# Altium schematic sheet MCU.SchDoc(MCU)
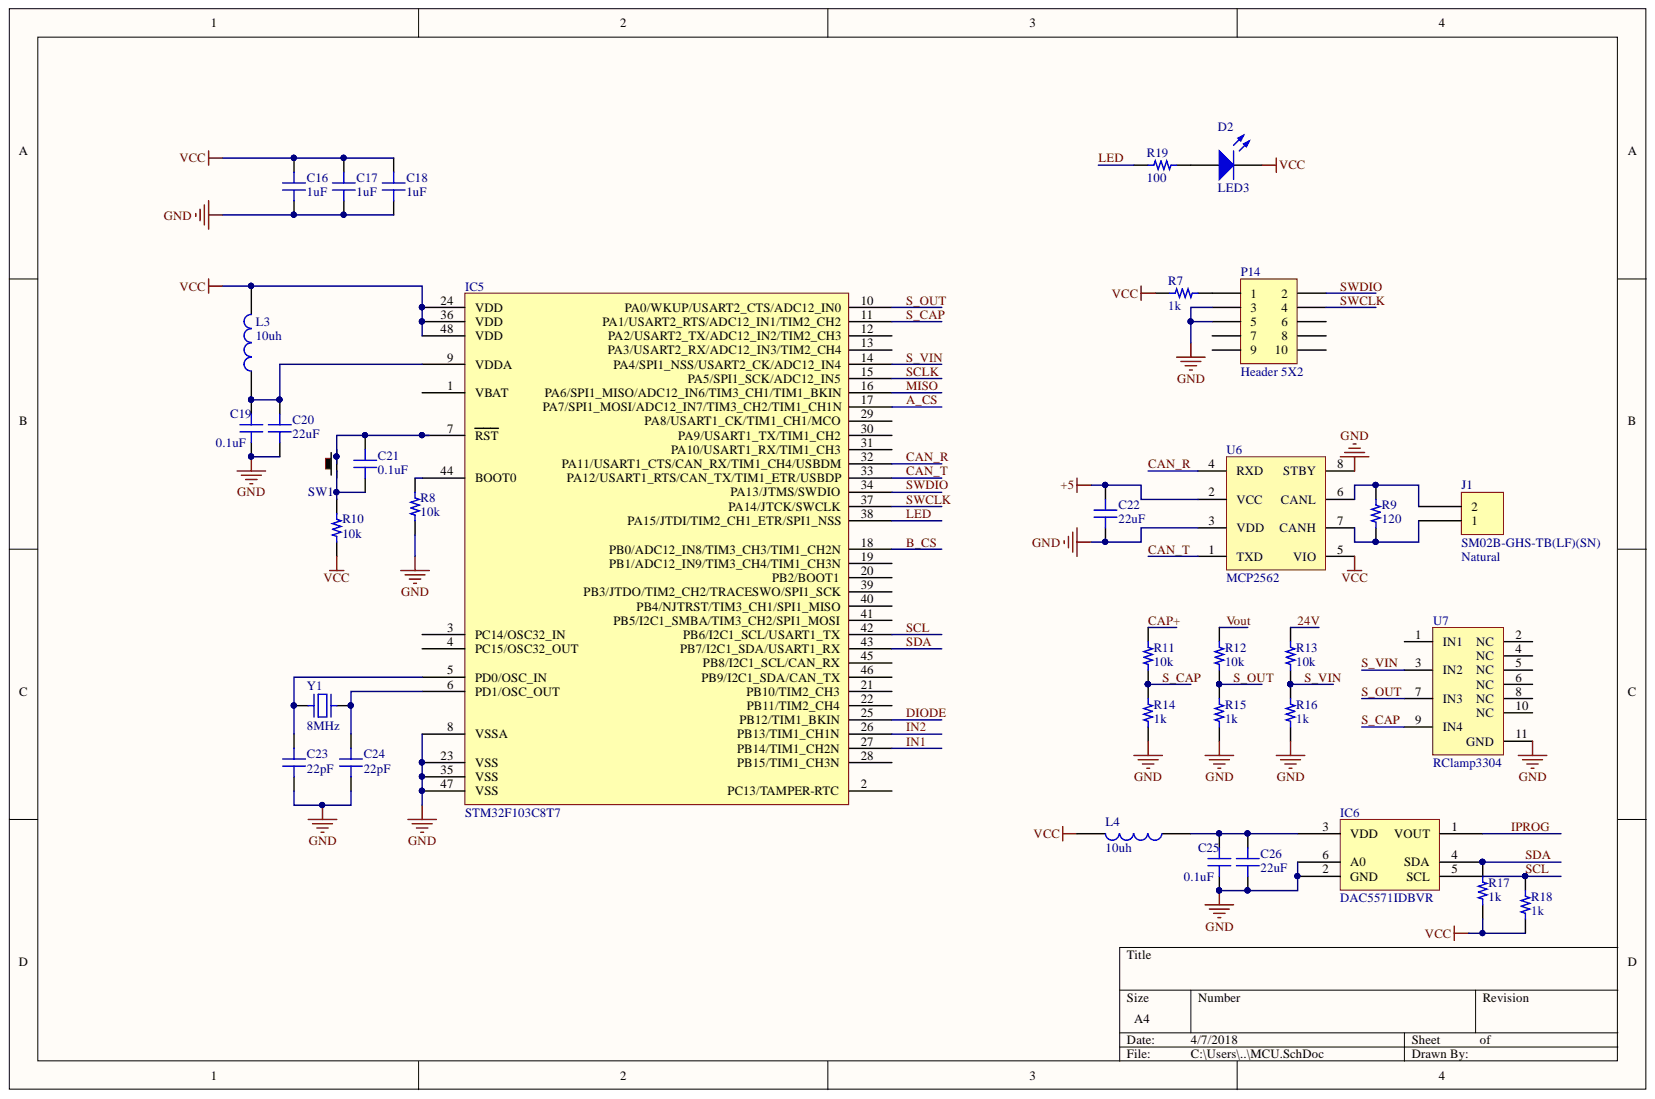
[diagram p0: MCU.SchDoc]

## Parts
C16  1uF  [CAP 0603/1608]
C17  1uF  [CAP 0603/1608]
C18  1uF  [CAP 0603/1608]
C19  0.1uF  [CAP 0603/1608]
C20  22uF  [CAP 0603/1608]
C21  0.1uF  [CAP 0603/1608]
C22  22uF  [CAP 0603/1608]
C23  22pF  [CAP 0603/1608]
C24  22pF  [CAP 0603/1608]
C25  0.1uF  [CAP 0603/1608]
C26  22uF  [CAP 0603/1608]
D2  LED3  [1608[0603]]
IC5  STM32F103C8T7  [ST LQFP-48 7x7MM]
IC6  DAC5571IDBVR  [TI SOT-23-6 DBV]
J1  SM02B-GHS-TB(LF)(SN)  Colour: Natural  [JST SM02B-GHS-TB]
L3  10uh  [1608[0603]]
L4  10uh  [1608[0603]]
P14  Header 5X2  [1.27 5x2 header swd]
R7  1k  [RES 0603/1608]
R8  10k  [RES 0603/1608]
R9  120  [RES 0805/2012]
R10  10k  [RES 0603/1608]
R11  10k  [RES 0603/1608]
R12  10k  [RES 0603/1608]
R13  10k  [RES 0603/1608]
R14  1k  [RES 0603/1608]
R15  1k  [RES 0603/1608]
R16  1k  [RES 0603/1608]
R17  1k  [RES 0603/1608]
R18  1k  [RES 0603/1608]
R19  100  [RES 0603/1608]
SW1  Button  [3.5x2.9 PushButton]  (value not drawn)
U6  MCP2562  [SOIC127P600X175-8N]
U7  RClamp3304  [SON50P260X65_HS-11N]
Y1  8MHz  [NDK NX5032GA]

## Nets
+5: C22.1 U6.2(VCC)
GND: C16.1 C17.1 C18.1 C19.1 C20.2 C22.2 C23.1 C24.1 C25.1 C26.2 IC5.8(VSSA) IC5.23(VSS) IC5.35(VSS) IC5.47(VSS) IC6.2(GND) IC6.6(A0) P14.3 P14.5 R8.1 R14.2 R15.2 R16.2 U6.3(VDD) U6.8(STBY) U7.11(GND)
VCC: C16.2 C17.2 C18.2 D2.2 IC5.24(VDD) IC5.36(VDD) IC5.48(VDD) L3.2 L4.2 R7.1 R10.1 R17.1 R18.1 U6.5(VIO)
24V: R13.2
A_CS: IC5.17(PA7/SPI1_MOSI/ADC12_IN7/TIM3_CH2/TIM1_CH1N)
B_CS: IC5.18(PB0/ADC12_IN8/TIM3_CH3/TIM1_CH2N)
CAN_R: IC5.32(PA11/USART1_CTS/CAN_RX/TIM1_CH4/USBDM) U6.4(RXD)
CAN_T: IC5.33(PA12/USART1_RTS/CAN_TX/TIM1_ETR/USBDP) U6.1(TXD)
CAP+: R11.2
DIODE: IC5.25(PB12/TIM1_BKIN)
IN1: IC5.27(PB14/TIM1_CH2N)
IN2: IC5.26(PB13/TIM1_CH1N)
IPROG: IC6.1(VOUT)
LED: IC5.38(PA15/JTDI/TIM2_CH1_ETR/SPI1_NSS) R19.1
MISO: IC5.16(PA6/SPI1_MISO/ADC12_IN6/TIM3_CH1/TIM1_BKIN)
S_CAP: IC5.11(PA1/USART2_RTS/ADC12_IN1/TIM2_CH2) R11.1 R14.1 U7.9(IN4)
S_OUT: IC5.10(PA0/WKUP/USART2_CTS/ADC12_IN0) R12.1 R15.1 U7.7(IN3)
S_VIN: IC5.14(PA4/SPI1_NSS/USART2_CK/ADC12_IN4) R13.1 R16.1 U7.3(IN2)
SCL: IC5.42(PB6/I2C1_SCL/USART1_TX) IC6.5(SCL) R18.2
SCLK: IC5.15(PA5/SPI1_SCK/ADC12_IN5)
SDA: IC5.43(PB7/I2C1_SDA/USART1_RX) IC6.4(SDA) R17.2
SWCLK: IC5.37(PA14/JTCK/SWCLK) P14.4
SWDIO: IC5.34(PA13/JTMS/SWDIO) P14.2
Vout: R12.2
NetC19_2: C19.2 C20.1 IC5.9(VDDA) L3.1
NetC21_1: C21.1 R10.2 SW1.1
NetC21_2: C21.2 IC5.7(RST) SW1.2
NetC23_2: C23.2 IC5.5(PD0/OSC_IN) Y1.1
NetC24_2: C24.2 IC5.6(PD1/OSC_OUT) Y1.2
NetC25_2: C25.2 C26.1 IC6.3(VDD) L4.1
NetD2_1: D2.1 R19.2
NetIC5_44: IC5.44(BOOT0) R8.2
NetJ1_1: J1.1 R9.2 U6.7(CANH)
NetJ1_2: J1.2 R9.1 U6.6(CANL)
NetP14_1: P14.1 R7.2

Not connected: IC5 1(VBAT) 2(PC13/TAMPER-RTC) 3(PC14/OSC32_IN) 4(PC15/OSC32_OUT) 12(PA2/USART2_TX/ADC12_IN2/TIM2_CH3) 13(PA3/USART2_RX/ADC12_IN3/TIM2_CH4) 19(PB1/ADC12_IN9/TIM3_CH4/TIM1_CH3N) 20(PB2/BOOT1) 21(PB10/TIM2_CH3) 22(PB11/TIM2_CH4) 28(PB15/TIM1_CH3N) 29(PA8/USART1_CK/TIM1_CH1/MCO) 30(PA9/USART1_TX/TIM1_CH2) 31(PA10/USART1_RX/TIM1_CH3) 39(PB3/JTDO/TIM2_CH2/TRACESWO/SPI1_SCK) 40(PB4/NJTRST/TIM3_CH1/SPI1_MISO) 41(PB5/I2C1_SMBA/TIM3_CH2/SPI1_MOSI) 45(PB8/I2C1_SCL/CAN_RX) 46(PB9/I2C1_SDA/CAN_TX); P14 6 7 8 9 10; U7 1(IN1) 2(NC) 4(NC) 5(NC) 6(NC) 8(NC) 10(NC)

## Library data of the parts
C16-C18 = C1608X7R1A105K080AC: CAP CER 1UF 10V X7R 0603; Manufacturer: TDK Corporation; Tolerance: 10%; TemperatureRange: -55C ~ 125C; Database Table Name: Capacitor - Ceramic; Voltage: 10V; Value: 1uF; Type: -; TemperatureCoefficient: X7R; Supplier Part Number 1: 445-5138-1-ND; Supplier 1: Digi-Key; Price: 0.14; Part Number: C1608X7R1A105K080AC; PartId: 4035; Library Name: Celestial Library Database.DbLib; Device Package: 0603 (1608 Metric); ComponentLink2URL: http://digikey.com/product-detail/en/C1608X7R1A105K080AC/445-5138-1-ND/2093753; ComponentLink2Description: Digi-Key listing; ComponentLink1URL: https://product.tdk.com/info/en/catalog/datasheets/mlcc_commercial_general_en.pdf; ComponentLink1Description: Datasheet; Component Kind: Standard
C19 C21 C25 = C1608X7R1E104K080AA: CAP CER 0.1UF 25V X7R 0603; Manufacturer: TDK Corporation; Tolerance: 10%; TemperatureRange: -55C ~ 125C; Database Table Name: Capacitor - Ceramic; Voltage: 25V; Value: 0.1uF; Type: -; TemperatureCoefficient: X7R; Supplier Part Number 1: 445-1316-1-ND; Supplier 1: Digi-Key; Price: 0.14; Part Number: C1608X7R1E104K080AA; PartId: 4029; Library Name: Celestial Library Database.DbLib; Device Package: 0603 (1608 Metric); ComponentLink2URL: http://digikey.com/product-detail/en/C1608X7R1E104K080AA/445-1316-1-ND/567697; ComponentLink2Description: Digi-Key listing; ComponentLink1URL: https://product.tdk.com/info/en/catalog/datasheets/mlcc_commercial_general_en.pdf; ComponentLink1Description: Datasheet; Component Kind: Standard
C20 C22 C26 = C1608X5R0J226M080AC: CAP CER 22UF 6.3V X5R 0603; Manufacturer: TDK Corporation; Tolerance: 20%; TemperatureRange: -55C ~ 85C; Database Table Name: Capacitor - Ceramic; Voltage: 6.3V; Value: 22uF; TemperatureCoefficient: X5R; Supplier Part Number 1: 445-8028-2-ND; Supplier 1: Digi-Key; Price: 0.0816; Part Number: C1608X5R0J226M080AC; PartId: 12992; Library Name: Celestial Library Database.DbLib; Device Package: 0603 (1608 Metric); ComponentLink2URL: https://www.digikey.com/product-detail/en/tdk-corporation/C1608X5R0J226M080AC/445-8028-2-ND/2792254; ComponentLink2Description: Digi-Key Listing; ComponentLink1URL: https://product.tdk.com/info/en/catalog/datasheets/mlcc_commercial_general_en.pdf; ComponentLink1Description: Datasheet; Component Kind: Standard
C23 C24 = C1608C0G1H220J080AA: CAP CER 22PF 50V C0G 0603; Manufacturer: TDK Corporation; Tolerance: 5%; TemperatureRange: -55C ~ 125C; TemperatureCoefficient: C0G, NP0; Database Table Name: Capacitor - Ceramic; Voltage: 50V; Value: 22pF; Type: -; Supplier Part Number 1: 445-1273-1-ND; Supplier 1: Digi-Key; Price: 0.14; Part Number: C1608C0G1H220J080AA; PartId: 4031; Library Name: Celestial Library Database.DbLib; Device Package: 0603 (1608 Metric); ComponentLink2URL: http://digikey.com/product-detail/en/C1608C0G1H220J080AA/445-1273-1-ND/567670; ComponentLink2Description: Digi-Key listing; ComponentLink1URL: https://product.tdk.com/info/en/catalog/datasheets/mlcc_commercial_general_en.pdf; ComponentLink1Description: Datasheet; Component Kind: Standard
D2 = LED3: Typical BLUE SiC LED; Simulation: LED3; Signal Integrity: LED; Published: 8-Jun-2000; PackageVersion: 16-Apr-2002; PackageReference: 3.5X2.8X1.9; PackageDescription: SM LED; 2 C-Bend Leads; Body 3.5 x 2.8 x 1.9 mm, inc leads (LxWxH typ); Library Name: Miscellaneous Devices.IntLib; LatestRevisionNote: PCB Footprint replaced.; LatestRevisionDate: 17-Dec-2003; DatasheetVersion: 16-Apr-2002; Component Kind: Standard; Publisher: Altium Limited
IC5 = STM32F103C8T7: IC MCU 32BIT 64KB FLASH 48LQFP; Manufacturer: STMicroelectronics; Voltage: 2 V ~ 3.6 V; Speed: 72MHz; Ram Size: 20K x 8; Program Memory Size: 64KB (64K x 8); Peripherals: DMA, Motor Control PWM, PDR, POR, PVD, PWM, Temp Sensor, WDT; Oscillator Type: Internal; Number Of IO: 37; Data Converters: A/D 10x12b; Database Table Name: MCU - ARM; Core Size: 32-Bit; Core Processor: ARM Cortex-M3; Connectivity: CAN, IC, IrDA, LIN, SPI, UART/USART, USB; Supplier Part Number 1: 497-11517-ND; Supplier 1: Digi-Key; Series: STM32 F1; Program Memory Type: FLASH; Price: 6.25; Part Number: STM32F103C8T7; PartId: 21165; Library Name: Celestial Library Database.DbLib; Device Package: 48-LQFP; ComponentLink2URL: https://www.digikey.com/product-detail/en/stmicroelectronics/STM32F103C8T7/497-11517-ND/2214603; ComponentLink2Description: Digi-Key Listing; ComponentLink1URL: //media.digikey.com/pdf/Data%20Sheets/ST%20Microelectronics%20PDFS/STM32F103x8,B.pdf; ComponentLink1Description: Datasheet; Component Kind: Standard
IC6 = DAC5571IDBVR: 8 BIT 12C SNGL CHNL DAC SOT23-6; Manufacturer: Texas Instruments; Number of Converters: 1; Number of Bits: 8; Data Interface: IC; Database Table Name: Digital to Analogue Converter; Type: 1 Voltage; Supplier Part Number 1: 296-38918-2-ND; Supplier 1: Digi-Key; Price: 1.0125; Part Number: DAC5571IDBVR; PartId: 9702; Operating Temperature: -40C ~ 105C; Library Name: Celestial Library Database.DbLib; Device Package: SOT-23-6; ComponentLink2URL: http://www.digikey.com/product-detail/en/texas-instruments/DAC5571IDBVR/296-38918-2-ND/1509001; ComponentLink2Description: Digi-Key Listing; ComponentLink1URL: http://www.ti.com/lit/ds/symlink/dac5571.pdf; ComponentLink1Description: Datasheet; Component Kind: Standard
J1 = SM02B-GHS-TB(LF)(SN): CONN HEADER GH SIDE 2POS 1.25MM; Manufacturer: JST Sales America Inc.; Pitch: 0.049" (1.25mm); Number Of Positions: 2; Database Table Name: Connector - Rectangular; Type: Surface Mount, Right Angle; Supplier Part Number 1: 455-1564-2-ND; Supplier 1: Digi-Key; Series: GH; Price: 0.2487; Part Number: SM02B-GHS-TB(LF)(SN); PartId: 7817; Number Of Rows: 1; Library Name: Celestial Library Database.DbLib; Fastening Type: Locking Ramp; Contact Finish: Tin; ComponentLink2URL: http://www.digikey.ca/product-detail/en/SM02B-GHS-TB(LF)(SN)/455-1564-2-ND/807786; ComponentLink2Description: Digi-Key Listing; ComponentLink1URL: http://www.jst-mfg.com/product/pdf/eng/eGH.pdf; ComponentLink1Description: Datasheet; Component Kind: Standard
L3 L4 = 电感: Component Kind: Standard; Library Name: MCUcomponent.SCHLIB
P14 = Header 5X2: Header, 5-Pin, Dual row; Signal Integrity: Connector; Library Name: Miscellaneous Connectors.IntLib; LatestRevisionNote: Re-released for DXP Platform.; LatestRevisionDate: 17-Jul-2002; Component Kind: Standard; Publisher: Altium Limited
R7 R14-R18 = ERJ-3EKF1001V: RES SMD 1K OHM 1% 1/10W 0603; Manufacturer: Panasonic Electronic Components; TemperatureRange: -55C ~ 155C; TemperatureCoefficient: 100ppm/C; PowerRating: 0.1W, 1/10W; Database Table Name: Resistor - Chip; Value: 1k; Type: Thick Film; Tolerance: 1%; Supplier Part Number 1: P1.00KHTR-ND; Supplier 1: Digi-Key; Price: 0.0038; Part Number: ERJ-3EKF1001V; PartId: 885; Library Name: Celestial Library Database.DbLib; Device Package: 0603 (1608 Metric); ComponentLink2URL: http://digikey.com/product-detail/en/ERJ-3EKF1001V/P1.00KHTR-ND/196032; ComponentLink2Description: Digi-Key listing; ComponentLink1URL: http://industrial.panasonic.com/www-cgi/jvcr13pz.cgi?E+PZ+3+AOA0002+ERJ3EKF1001V+7+WW; ComponentLink1Description: Datasheet; Component Kind: Standard
R8 = ERJ-3GEYJ103V: RES SMD 10K OHM 5% 1/10W 0603; Manufacturer: Panasonic Electronic Components; TemperatureRange: -55C ~ 155C; TemperatureCoefficient: 200ppm/C; PowerRating: 0.1W, 1/10W; Database Table Name: Resistor - Chip; Value: 10k; Tolerance: 5%; Supplier Part Number 1: P10KGTR-ND; Supplier 1: Digi-Key; Price: 0.002; Part Number: ERJ-3GEYJ103V; PartId: 11175; Library Name: Celestial Library Database.DbLib; Device Package: 0603 (1608 Metric); ComponentLink2URL: https://www.digikey.com/product-detail/en/panasonic-electronic-components/ERJ-3GEYJ103V/P10KGTR-ND/135662; ComponentLink2Description: Digi-Key Listing; ComponentLink1URL: http://industrial.panasonic.com/www-cgi/jvcr13pz.cgi?E+PZ+3+AOA0001+ERJ3GEYJ103V+7+WW; ComponentLink1Description: Datasheet; Component Kind: Standard
R9 = ERA-6AEB121V: RES SMD 120 OHM 0.1% 1/8W 0805; Manufacturer: Panasonic Electronic Components; TemperatureRange: -55C ~ 155C; TemperatureCoefficient: 25ppm/C; PowerRating: 0.125W, 1/8W; Database Table Name: Resistor - Chip; Value: 120; Type: Thin Film; Tolerance: 0.1%; Supplier Part Number 1: P120DATR-ND; Supplier 1: Digi-Key; Price: 0.055; Part Number: ERA-6AEB121V; PartId: 2533; Library Name: Celestial Library Database.DbLib; Device Package: 0805 (2012 Metric); ComponentLink2URL: http://digikey.com/product-detail/en/ERA-6AEB121V/P120DATR-ND/1465726; ComponentLink2Description: Digi-Key listing; ComponentLink1URL: http://industrial.panasonic.com/lecs/www-data/pdf/AOA0000/AOA0000CE26.pdf; ComponentLink1Description: Datasheet; Component Kind: Standard
R10-R13 = ERJ-3EKF1002V: RES SMD 10K OHM 1% 1/10W 0603; Manufacturer: Panasonic Electronic Components; TemperatureRange: -55C ~ 155C; TemperatureCoefficient: 100ppm/C; PowerRating: 0.1W, 1/10W; Database Table Name: Resistor - Chip; Value: 10k; Type: Thick Film; Tolerance: 1%; Supplier Part Number 1: P10.0KHTR-ND; Supplier 1: Digi-Key; Price: 0.0038; Part Number: ERJ-3EKF1002V; PartId: 886; Library Name: Celestial Library Database.DbLib; Device Package: 0603 (1608 Metric); ComponentLink2URL: http://digikey.com/product-detail/en/ERJ-3EKF1002V/P10.0KHTR-ND/196066; ComponentLink2Description: Digi-Key listing; ComponentLink1URL: http://industrial.panasonic.com/www-cgi/jvcr13pz.cgi?E+PZ+3+AOA0002+ERJ3EKF1002V+7+WW; ComponentLink1Description: Datasheet; Component Kind: Standard
R19 = ERJ-3GEYJ101V: RES SMD 100 OHM 5% 1/10W 0603; Manufacturer: Panasonic Electronic Components; TemperatureRange: -55C ~ 155C; TemperatureCoefficient: 200ppm/C; PowerRating: 0.1W, 1/10W; Database Table Name: Resistor - Chip; Value: 100; Tolerance: 5%; Supplier Part Number 1: P100GTR-ND; Supplier 1: Digi-Key; Price: 0.002; Part Number: ERJ-3GEYJ101V; PartId: 11179; Library Name: Celestial Library Database.DbLib; Device Package: 0603 (1608 Metric); ComponentLink2URL: https://www.digikey.com/product-detail/en/panasonic-electronic-components/ERJ-3GEYJ101V/P100GTR-ND/135659; ComponentLink2Description: Digi-Key Listing; ComponentLink1URL: http://industrial.panasonic.com/www-cgi/jvcr13pz.cgi?E+PZ+3+AOA0001+ERJ3GEYJ101V+7+WW; ComponentLink1Description: Datasheet; Component Kind: Standard
Y1 = NX5032GA-8.000M-STD-CSU-1: CRYSTAL 8.0000MHZ 8PF SMD; Manufacturer: NDK; Operating Mode: Fundamental; Frequency Tolerance: 50ppm; Frequency Stability: 150ppm; ESR: 300 Ohm; Database Table Name: Oscillator - Crystal; Type: MHz Crystal; Supplier Part Number 1: 644-1132-2-ND; Supplier 1: Digi-Key; Price: 0.63; Part Number: NX5032GA-8.000M-STD-CSU-1; PartId: 8473; Load Capacitance: 8pF; Library Name: Celestial Library Database.DbLib; Frequency: 8MHz; Device Package: 2-SMD; ComponentLink2URL: http://www.digikey.com/product-detail/en/NX5032GA-8.000M-STD-CSU-1/644-1132-2-ND/1788472; ComponentLink2Description: Digi-Key Listing; ComponentLink1URL: http://www.ndk.com/images/products/catalog/c_NX5032GA-STD-CSU-2_e.pdf; ComponentLink1Description: Datasheet; Component Kind: Standard
SW1 = Button: Component Kind: Standard; Library Name: Hand COmponent Libary.SchLib
U6 = MCP2562: Library Name: Power Parts.SchLib; Component Kind: Standard
U7 = RClamp3304: Library Name: Power Parts.SchLib; Component Kind: Standard
